# Altium schematic sheet LoRa_Elemon_4.SchDoc(LoRa_Elemon_4)
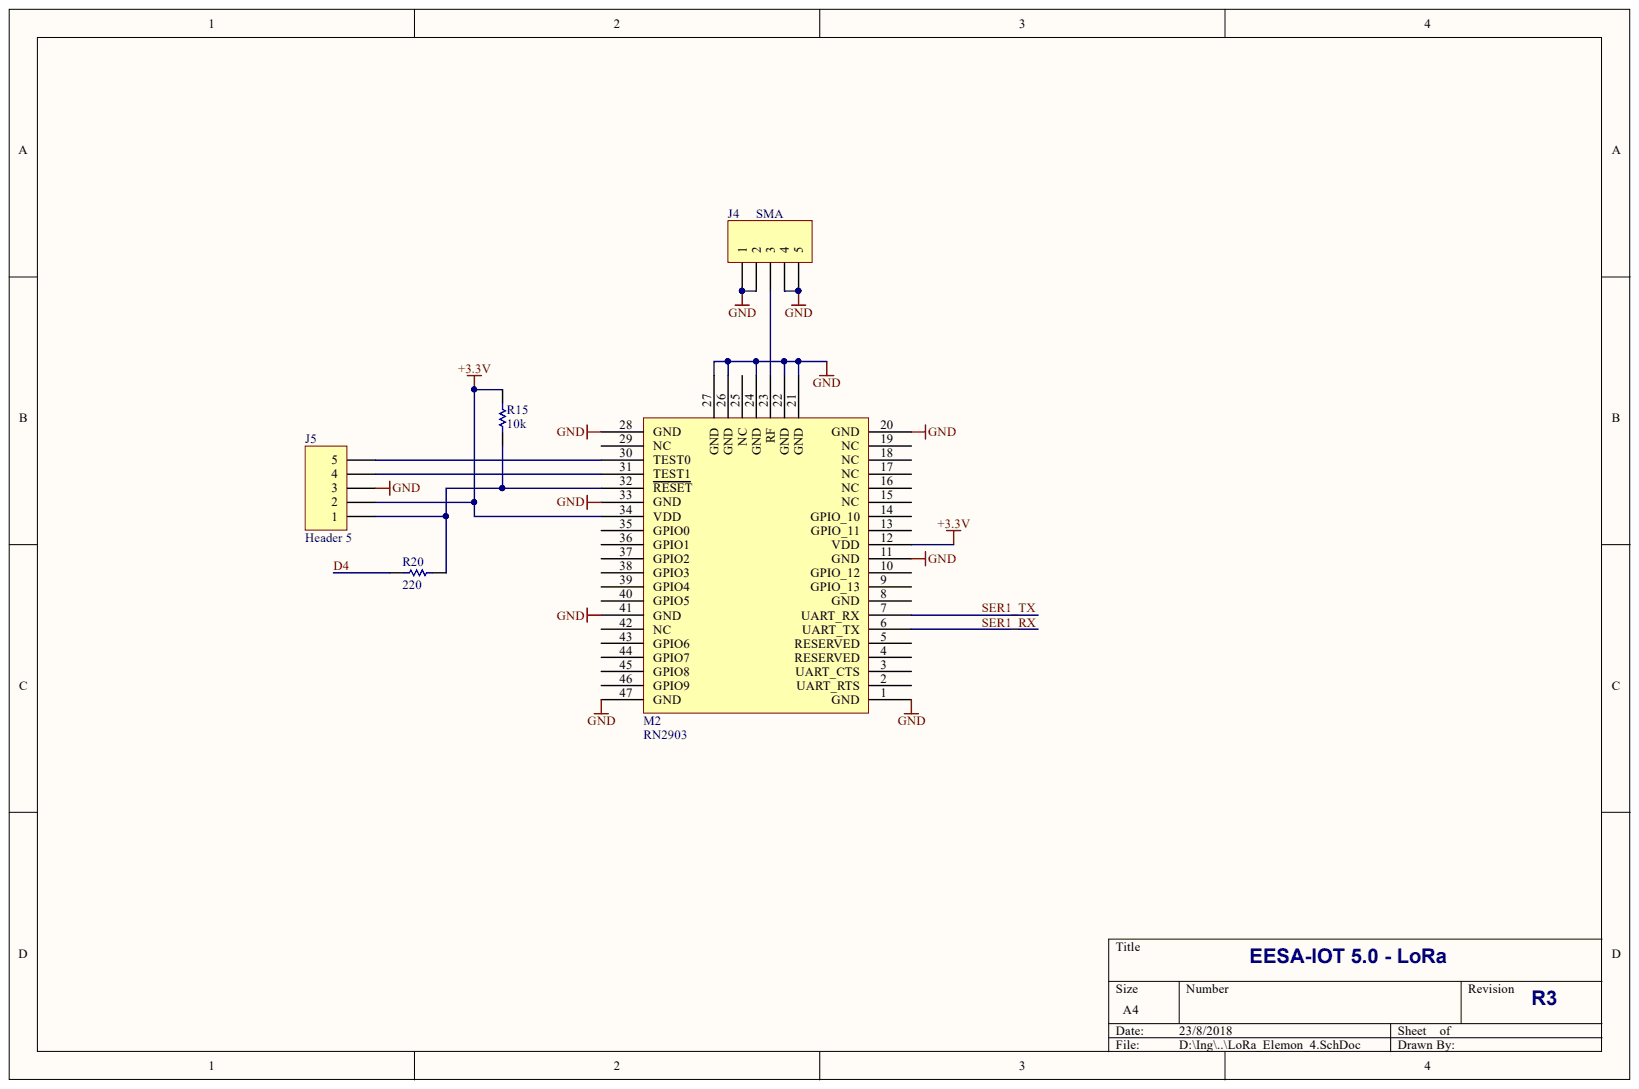
[diagram p0: LoRa_Elemon_4.SchDoc]

## Parts
J4  SMA  [sma-5]
J5  Header 5  [HDR1X5]
M2  RN2903  [RN2903]
R15  10k  [0805]
R20  220  [0805]

## Nets
+3.3V: J5.2 M2.12(VDD) M2.34(VDD) R15.2
GND: J4.1 J4.2 J4.4 J4.5 J5.3 M2.1(GND) M2.11(GND) M2.20(GND) M2.21(GND) M2.22(GND) M2.24(GND) M2.26(GND) M2.27(GND) M2.28(GND) M2.33(GND) M2.41(GND) M2.47(GND)
D4: R20.2
SER1_RX: M2.6(UART_TX)
SER1_TX: M2.7(UART_RX)
NetJ4_3: J4.3 M2.23(RF)
NetJ5_1: J5.1 M2.32(RESET) R15.1 R20.1
NetJ5_4: J5.4 M2.31(TEST1)
NetJ5_5: J5.5 M2.30(TEST0)

Not connected: M2 2(UART_RTS) 3(UART_CTS) 4(RESERVED) 5(RESERVED) 8(GND) 9(GPIO_13) 10(GPIO_12) 13(GPIO_11) 14(GPIO_10) 15(NC) 16(NC) 17(NC) 18(NC) 19(NC) 25(NC) 29(NC) 35(GPIO0) 36(GPIO1) 37(GPIO2) 38(GPIO3) 39(GPIO4) 40(GPIO5) 42(NC) 43(GPIO6) 44(GPIO7) 45(GPIO8) 46(GPIO9)

## Library data of the parts
J4 J5 = Header 5: Header, 5-Pin; Component Kind: Standard; LatestRevisionDate: 17-Jul-2002; LatestRevisionNote: Re-released for DXP Platform.; Library Name: Miscellaneous Connectors.IntLib; Signal Integrity: Connector; Publisher: Altium Limited
M2 = RN2903: Lora Module; Component Kind: Standard; Library Name: LoRa_Elemon.SchLib
R15 R20 = Res1: Resistor; Value: 1K; Component Kind: Standard; LatestRevisionDate: 17-Jul-2002; LatestRevisionNote: Re-released for DXP Platform.; Library Name: Miscellaneous Devices.IntLib; PackageDescription: Axial Device, Thru-Hole; 2 Leads; 0.3 in Pin Spacing; PackageReference: AXIAL-0.3; Published: 8-Jun-2000; Signal Integrity: Res; Simulation: RESISTOR; Publisher: Altium Limited
